# Altium schematic sheet Actuation.SchDoc
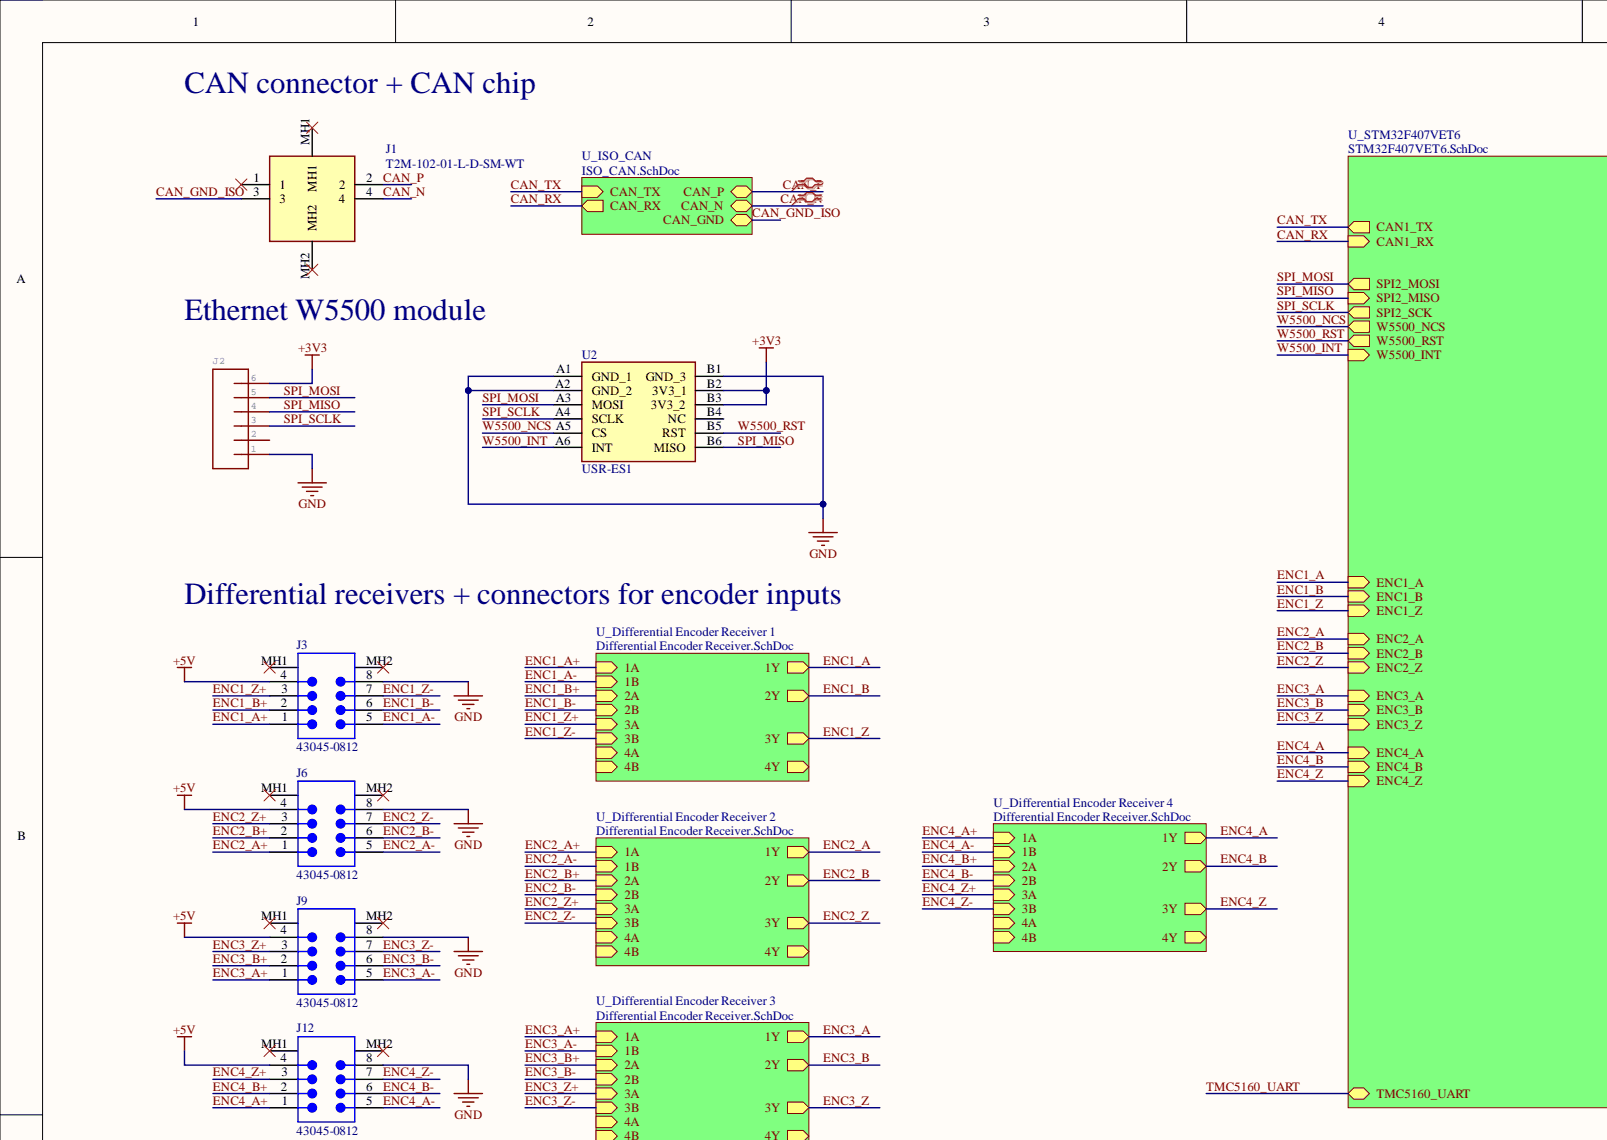
[diagram p0: Actuation.SchDoc, top left]
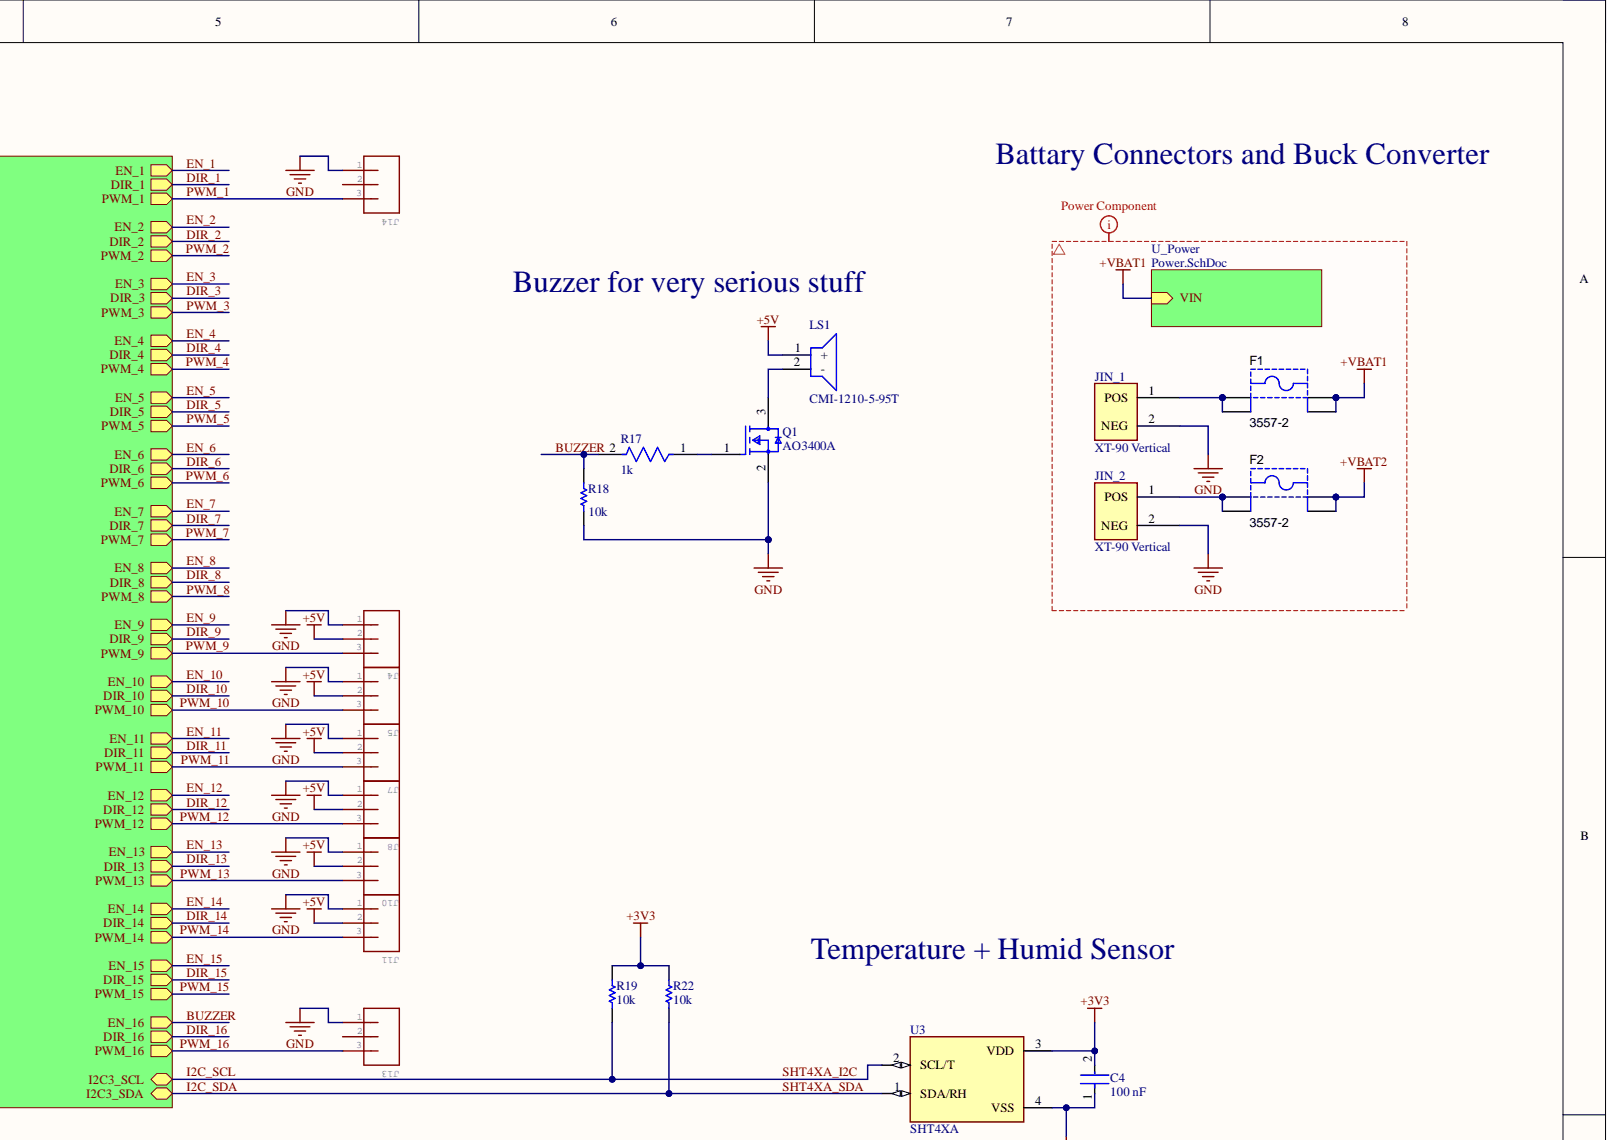
[diagram p1: Actuation.SchDoc, top right]
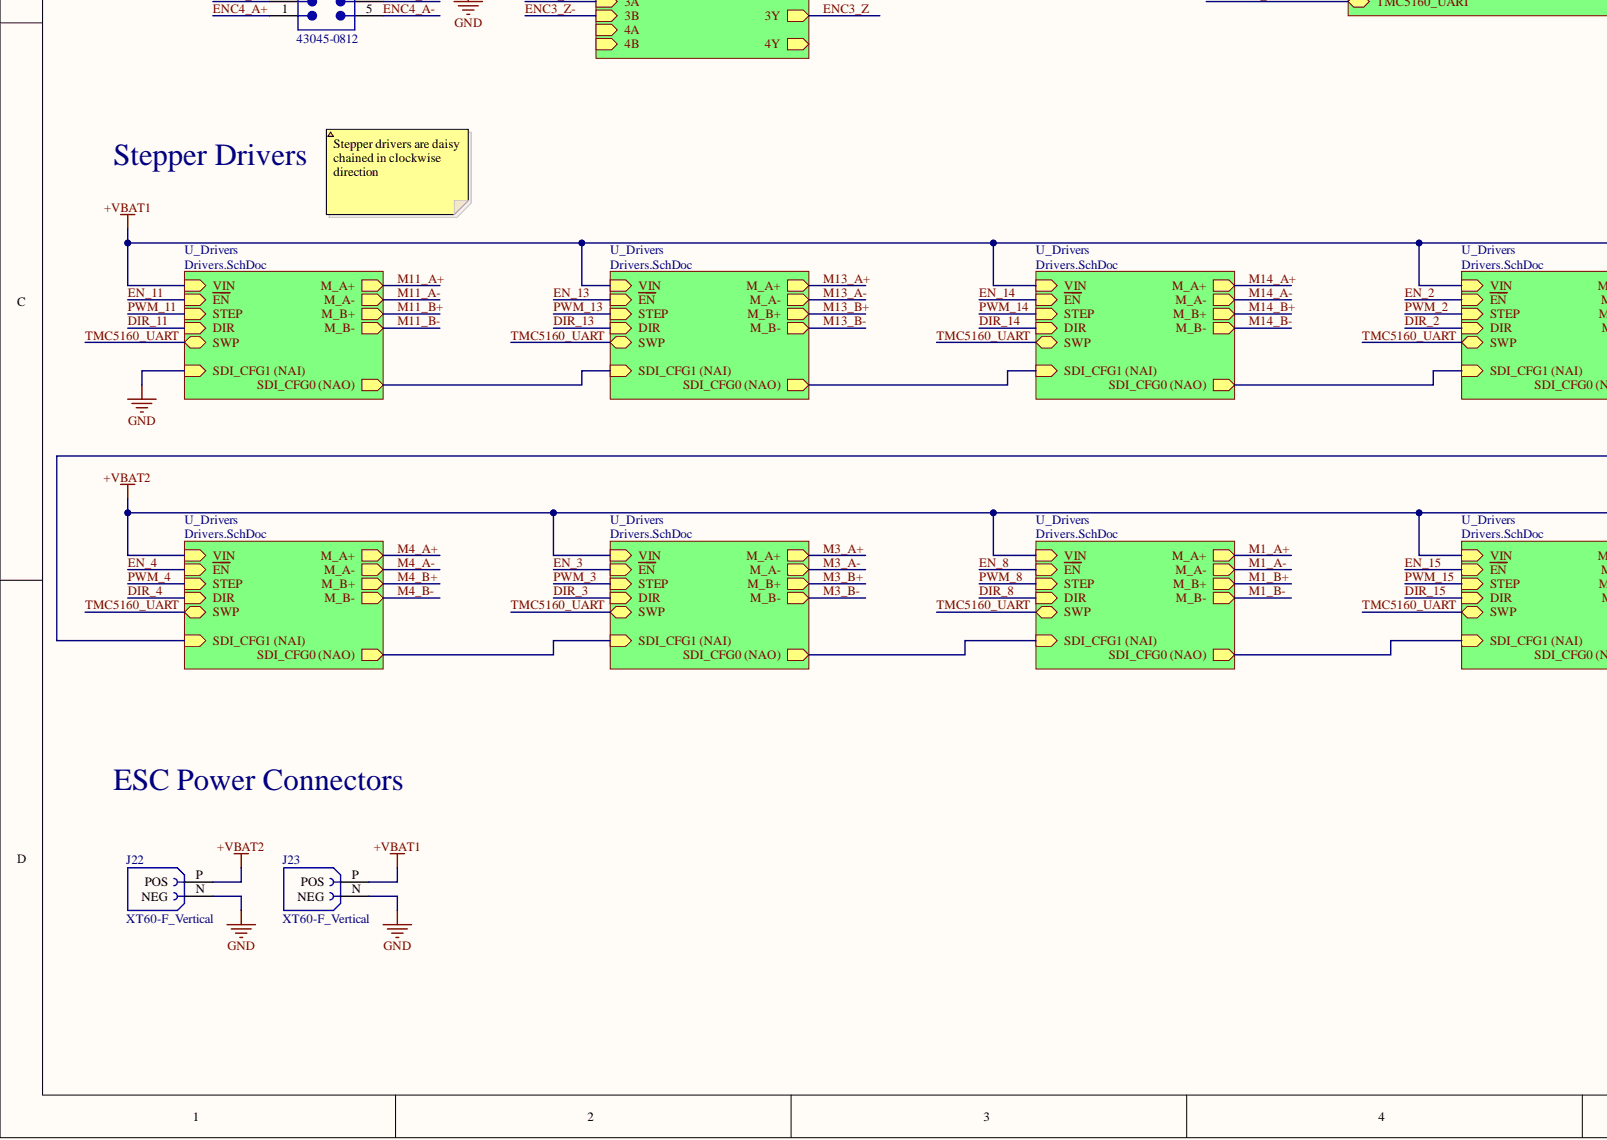
[diagram p2: Actuation.SchDoc, bottom left]
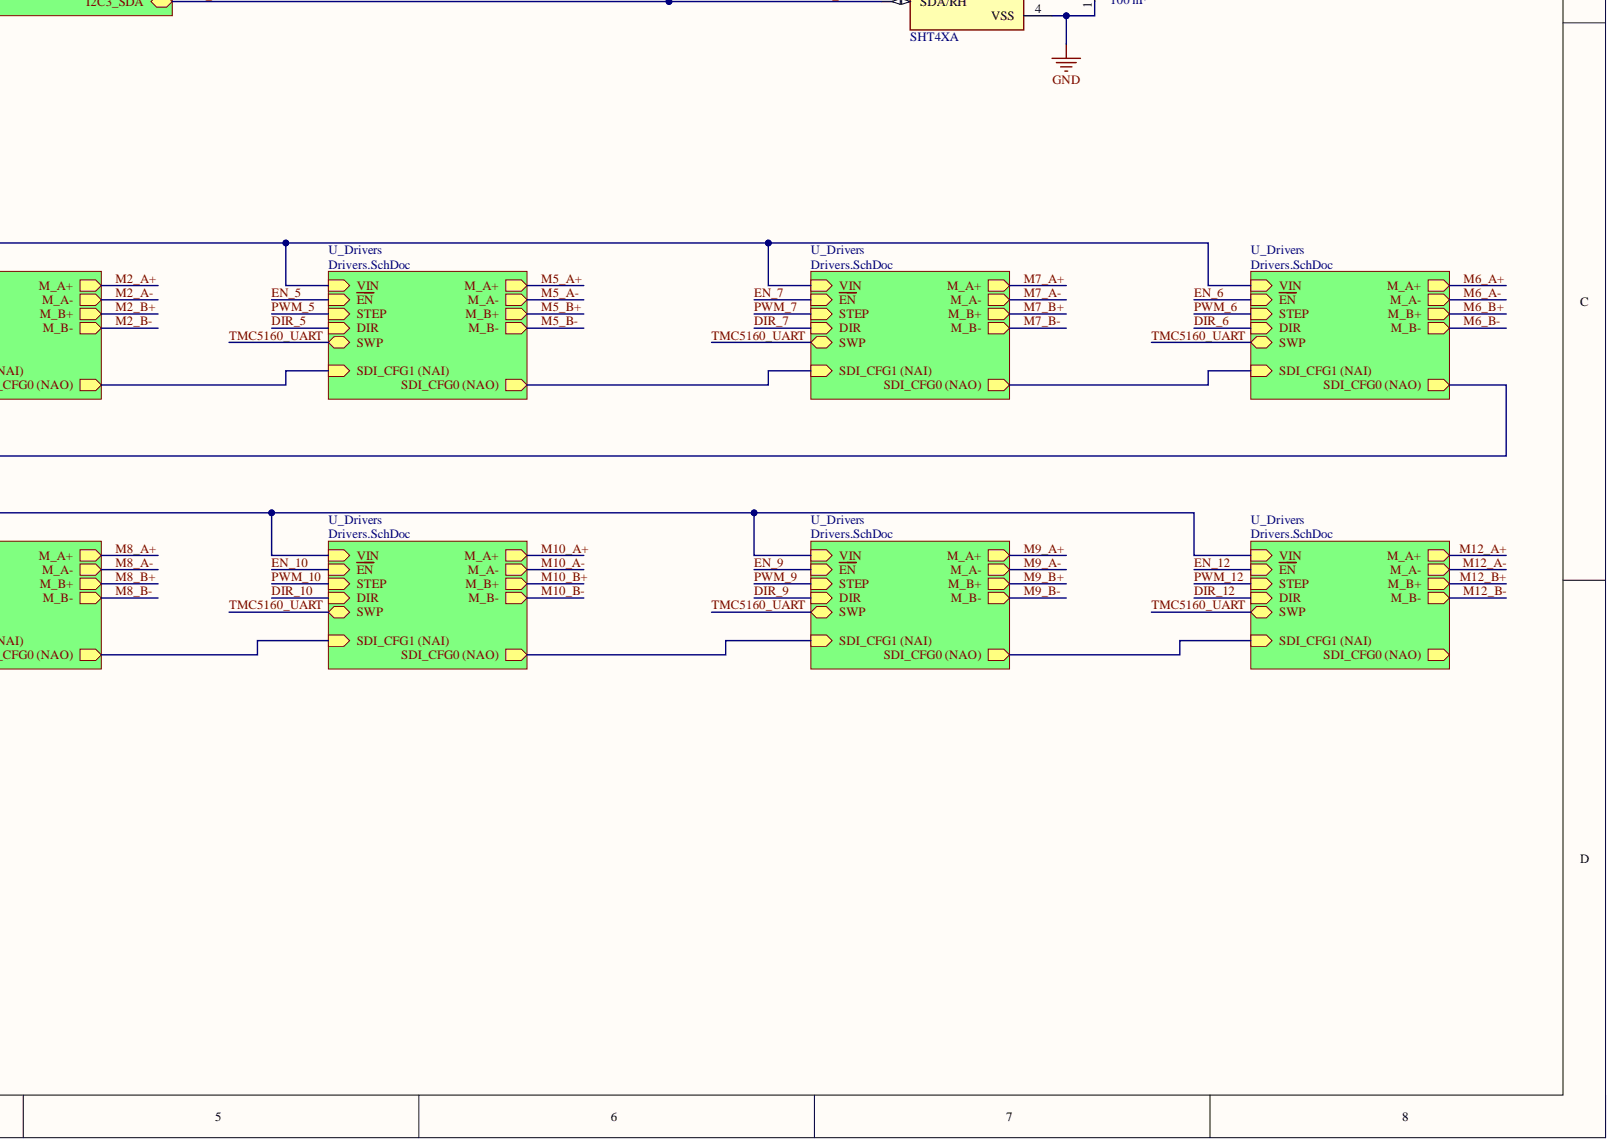
[diagram p3: Actuation.SchDoc, bottom right]

## Parts
C4  value 100 nF  Capacitance: 100 nF  [FP-CC0603-DA-MFG]  (hidden comment: CC0603KRX7R9BB104)
F1  3557-2  [KSTN-3557-2]
F2  3557-2  [KSTN-3557-2]
J1  T2M-102-01-L-D-SM-WT  [T2M10201LDSMWT]
J2  Multi connection point. Often used as Generic Header-pin footprint for 0.1 inch spaced/style header connections    On any of the 0.1 inch spaced packages, you can populate with these:  Break Away Headers - Straight (PRT-00116)   Break Away Male Headers - Right Angle (PRT-00553)   Female Headers (PRT-00115)   Break Away Headers - Machine Pin (PRT-00117)   Break Away Female Headers - Swiss Machine Pin (PRT-00743)   Arduino Stackable Header - 6 Pin (PRT-09280)   Screw Terminals 2.54mm Pitch (6-Pin) (PRT-16499)        For SCREWTERMINALS and SPRING TERMINALS visit here:  Screw Terimnals on SparkFun.com (5mm/3.5mm/2.54mm spacing)       This device is also useful as a general connection point to wire up your design to another part of your project. Our various solder wires solder well into these plated through hole pads.  Hook-Up Wire - Assortment (Stranded, 22 AWG) (PRT-11375)   Hook-Up Wire - Assortment (Solid Core, 22 AWG) (PRT-11367)   View the entire wire category on our website here  [1X06_LOCK]  (value not drawn)
J3  43045-0812  [MOLX-43045-0812_V]
J4  Multi connection point. Often used as Generic Header-pin footprint for 0.1 inch spaced/style header connections    On any of the 0.1 inch spaced packages, you can populate with these:  Break Away Headers - Straight (PRT-00116)   Break Away Male Headers - Right Angle (PRT-00553)   Female Headers (PRT-00115)   Break Away Headers - Machine Pin (PRT-00117)   Break Away Female Headers - Swiss Machine Pin (PRT-00743)   Stackable Header - 3 Pin (Female, 0.1") (PRT-13875)        For SCREWTERMINALS and SPRING TERMINALS visit here:  Screw Terimnals on SparkFun.com (5mm/3.5mm/2.54mm spacing)       This device is also useful as a general connection point to wire up your design to another part of your project. Our various solder wires solder well into these plated through hole pads.  Hook-Up Wire - Assortment (Stranded, 22 AWG) (PRT-11375)   Hook-Up Wire - Assortment (Solid Core, 22 AWG) (PRT-11367)   View the entire wire category on our website here          Special notes:         Molex polarized connector foot print use with SKU : PRT-08232 with associated crimp pins and housings.  [1X03_LOCK]  (value not drawn)
J5  Multi connection point. Often used as Generic Header-pin footprint for 0.1 inch spaced/style header connections    On any of the 0.1 inch spaced packages, you can populate with these:  Break Away Headers - Straight (PRT-00116)   Break Away Male Headers - Right Angle (PRT-00553)   Female Headers (PRT-00115)   Break Away Headers - Machine Pin (PRT-00117)   Break Away Female Headers - Swiss Machine Pin (PRT-00743)   Stackable Header - 3 Pin (Female, 0.1") (PRT-13875)        For SCREWTERMINALS and SPRING TERMINALS visit here:  Screw Terimnals on SparkFun.com (5mm/3.5mm/2.54mm spacing)       This device is also useful as a general connection point to wire up your design to another part of your project. Our various solder wires solder well into these plated through hole pads.  Hook-Up Wire - Assortment (Stranded, 22 AWG) (PRT-11375)   Hook-Up Wire - Assortment (Solid Core, 22 AWG) (PRT-11367)   View the entire wire category on our website here          Special notes:         Molex polarized connector foot print use with SKU : PRT-08232 with associated crimp pins and housings.  [1X03_LOCK]  (value not drawn)
J6  43045-0812  [MOLX-43045-0812_V]
J7  Multi connection point. Often used as Generic Header-pin footprint for 0.1 inch spaced/style header connections    On any of the 0.1 inch spaced packages, you can populate with these:  Break Away Headers - Straight (PRT-00116)   Break Away Male Headers - Right Angle (PRT-00553)   Female Headers (PRT-00115)   Break Away Headers - Machine Pin (PRT-00117)   Break Away Female Headers - Swiss Machine Pin (PRT-00743)   Stackable Header - 3 Pin (Female, 0.1") (PRT-13875)        For SCREWTERMINALS and SPRING TERMINALS visit here:  Screw Terimnals on SparkFun.com (5mm/3.5mm/2.54mm spacing)       This device is also useful as a general connection point to wire up your design to another part of your project. Our various solder wires solder well into these plated through hole pads.  Hook-Up Wire - Assortment (Stranded, 22 AWG) (PRT-11375)   Hook-Up Wire - Assortment (Solid Core, 22 AWG) (PRT-11367)   View the entire wire category on our website here          Special notes:         Molex polarized connector foot print use with SKU : PRT-08232 with associated crimp pins and housings.  [1X03_LOCK]  (value not drawn)
J8  Multi connection point. Often used as Generic Header-pin footprint for 0.1 inch spaced/style header connections    On any of the 0.1 inch spaced packages, you can populate with these:  Break Away Headers - Straight (PRT-00116)   Break Away Male Headers - Right Angle (PRT-00553)   Female Headers (PRT-00115)   Break Away Headers - Machine Pin (PRT-00117)   Break Away Female Headers - Swiss Machine Pin (PRT-00743)   Stackable Header - 3 Pin (Female, 0.1") (PRT-13875)        For SCREWTERMINALS and SPRING TERMINALS visit here:  Screw Terimnals on SparkFun.com (5mm/3.5mm/2.54mm spacing)       This device is also useful as a general connection point to wire up your design to another part of your project. Our various solder wires solder well into these plated through hole pads.  Hook-Up Wire - Assortment (Stranded, 22 AWG) (PRT-11375)   Hook-Up Wire - Assortment (Solid Core, 22 AWG) (PRT-11367)   View the entire wire category on our website here          Special notes:         Molex polarized connector foot print use with SKU : PRT-08232 with associated crimp pins and housings.  [1X03_LOCK]  (value not drawn)
J9  43045-0812  [MOLX-43045-0812_V]
J10  Multi connection point. Often used as Generic Header-pin footprint for 0.1 inch spaced/style header connections    On any of the 0.1 inch spaced packages, you can populate with these:  Break Away Headers - Straight (PRT-00116)   Break Away Male Headers - Right Angle (PRT-00553)   Female Headers (PRT-00115)   Break Away Headers - Machine Pin (PRT-00117)   Break Away Female Headers - Swiss Machine Pin (PRT-00743)   Stackable Header - 3 Pin (Female, 0.1") (PRT-13875)        For SCREWTERMINALS and SPRING TERMINALS visit here:  Screw Terimnals on SparkFun.com (5mm/3.5mm/2.54mm spacing)       This device is also useful as a general connection point to wire up your design to another part of your project. Our various solder wires solder well into these plated through hole pads.  Hook-Up Wire - Assortment (Stranded, 22 AWG) (PRT-11375)   Hook-Up Wire - Assortment (Solid Core, 22 AWG) (PRT-11367)   View the entire wire category on our website here          Special notes:         Molex polarized connector foot print use with SKU : PRT-08232 with associated crimp pins and housings.  [1X03_LOCK]  (value not drawn)
J11  Multi connection point. Often used as Generic Header-pin footprint for 0.1 inch spaced/style header connections    On any of the 0.1 inch spaced packages, you can populate with these:  Break Away Headers - Straight (PRT-00116)   Break Away Male Headers - Right Angle (PRT-00553)   Female Headers (PRT-00115)   Break Away Headers - Machine Pin (PRT-00117)   Break Away Female Headers - Swiss Machine Pin (PRT-00743)   Stackable Header - 3 Pin (Female, 0.1") (PRT-13875)        For SCREWTERMINALS and SPRING TERMINALS visit here:  Screw Terimnals on SparkFun.com (5mm/3.5mm/2.54mm spacing)       This device is also useful as a general connection point to wire up your design to another part of your project. Our various solder wires solder well into these plated through hole pads.  Hook-Up Wire - Assortment (Stranded, 22 AWG) (PRT-11375)   Hook-Up Wire - Assortment (Solid Core, 22 AWG) (PRT-11367)   View the entire wire category on our website here          Special notes:         Molex polarized connector foot print use with SKU : PRT-08232 with associated crimp pins and housings.  [1X03_LOCK]  (value not drawn)
J12  43045-0812  [MOLX-43045-0812_V]
J13  Multi connection point. Often used as Generic Header-pin footprint for 0.1 inch spaced/style header connections    On any of the 0.1 inch spaced packages, you can populate with these:  Break Away Headers - Straight (PRT-00116)   Break Away Male Headers - Right Angle (PRT-00553)   Female Headers (PRT-00115)   Break Away Headers - Machine Pin (PRT-00117)   Break Away Female Headers - Swiss Machine Pin (PRT-00743)   Stackable Header - 3 Pin (Female, 0.1") (PRT-13875)        For SCREWTERMINALS and SPRING TERMINALS visit here:  Screw Terimnals on SparkFun.com (5mm/3.5mm/2.54mm spacing)       This device is also useful as a general connection point to wire up your design to another part of your project. Our various solder wires solder well into these plated through hole pads.  Hook-Up Wire - Assortment (Stranded, 22 AWG) (PRT-11375)   Hook-Up Wire - Assortment (Solid Core, 22 AWG) (PRT-11367)   View the entire wire category on our website here          Special notes:         Molex polarized connector foot print use with SKU : PRT-08232 with associated crimp pins and housings.  [1X03_LOCK]  (value not drawn)
J14  Multi connection point. Often used as Generic Header-pin footprint for 0.1 inch spaced/style header connections    On any of the 0.1 inch spaced packages, you can populate with these:  Break Away Headers - Straight (PRT-00116)   Break Away Male Headers - Right Angle (PRT-00553)   Female Headers (PRT-00115)   Break Away Headers - Machine Pin (PRT-00117)   Break Away Female Headers - Swiss Machine Pin (PRT-00743)   Stackable Header - 3 Pin (Female, 0.1") (PRT-13875)        For SCREWTERMINALS and SPRING TERMINALS visit here:  Screw Terimnals on SparkFun.com (5mm/3.5mm/2.54mm spacing)       This device is also useful as a general connection point to wire up your design to another part of your project. Our various solder wires solder well into these plated through hole pads.  Hook-Up Wire - Assortment (Stranded, 22 AWG) (PRT-11375)   Hook-Up Wire - Assortment (Solid Core, 22 AWG) (PRT-11367)   View the entire wire category on our website here          Special notes:         Molex polarized connector foot print use with SKU : PRT-08232 with associated crimp pins and housings.  [1X03_LOCK]  (value not drawn)
J22  XT60-F_Vertical  [AMASS_XT60-F_1x02_P7.20mm_Vertical]
J23  XT60-F_Vertical  [AMASS_XT60-F_1x02_P7.20mm_Vertical]
JIN_1  XT-90 Vertical  [XT-90 Vertical-Footprint-1]
JIN_2  XT-90 Vertical  [XT-90 Vertical-Footprint-1]
LS1  CMI-1210-5-95T  [CMI1210595T]
Q1  AO3400A  [FP-SOT23-MFG]
R17  1k  [FP-RC0603-0_55-IPC_C]
R18  Resistance: 10k  [RESC1609X50X30NL8T20]  (hidden comment: 0603WAF1002T5E)
R19  Resistance: 10k  [RESC1609X50X30NL8T20]  (hidden comment: 0603WAF1002T5E)
R22  Resistance: 10k  [RESC1609X50X30NL8T20]  (hidden comment: 0603WAF1002T5E)
U2  USR-ES1  [USRES1]
U3  SHT4XA  [SHT4XA]

## Nets
+3V3: C4.2 J2.6 R19.2 R22.2 U2.B2(3V3_1) U2.B3(3V3_2) U3.3(VDD)
+5V: J3.4 J4.2 J5.2 J6.4 J7.2 J8.2 J9.4 J10.2 J11.2 J12.4 LS1.1
+VBAT1: F1.3 F1.4 J23.P
+VBAT2: F2.3 F2.4 J22.P
GND: C4.1 J2.1 J3.8 J4.1 J5.1 J6.8 J7.1 J8.1 J9.8 J10.1 J11.1 J12.8 J13.1 J14.1 J22.N J23.N JIN_1.2 JIN_2.2 Q1.2 R18.2 U2.A1(GND_1) U2.A2(GND_2) U2.B1(GND_3) U3.4(VSS)
BUZZER: R17.2 R18.1
CAN_GND_ISO: J1.3
CAN_N: J1.4
CAN_P: J1.2
ENC1_A+: J3.1
ENC1_A-: J3.5
ENC1_B+: J3.2
ENC1_B-: J3.6
ENC1_Z+: J3.3
ENC1_Z-: J3.7
ENC2_A+: J6.1
ENC2_A-: J6.5
ENC2_B+: J6.2
ENC2_B-: J6.6
ENC2_Z+: J6.3
ENC2_Z-: J6.7
ENC3_A+: J9.1
ENC3_A-: J9.5
ENC3_B+: J9.2
ENC3_B-: J9.6
ENC3_Z+: J9.3
ENC3_Z-: J9.7
ENC4_A+: J12.1
ENC4_A-: J12.5
ENC4_B+: J12.2
ENC4_B-: J12.6
ENC4_Z+: J12.3
ENC4_Z-: J12.7
I2C_SCL: R19.1 U3.2(SCL/T)
I2C_SDA: R22.1 U3.1(SDA/RH)
PWM_1: J14.3
PWM_9: J4.3
PWM_10: J5.3
PWM_11: J7.3
PWM_12: J8.3
PWM_13: J10.3
PWM_14: J11.3
PWM_16: J13.3
SPI_MISO: J2.4 U2.B6(MISO)
SPI_MOSI: J2.5 U2.A3(MOSI)
SPI_SCLK: J2.3 U2.A4(SCLK)
W5500_INT: U2.A6(INT)
W5500_NCS: U2.A5(CS)
W5500_RST: U2.B5(RST)
NetF1_1: F1.1 F1.2 JIN_1.1
NetF2_1: F2.1 F2.2 JIN_2.1
NetJ1_1: J1.1
NetJ1_MH1: J1.MH1
NetJ1_MH2: J1.MH2
NetJ2_2: J2.2
NetJ3_MH1: J3.MH1
NetJ3_MH2: J3.MH2
NetJ6_MH1: J6.MH1
NetJ6_MH2: J6.MH2
NetJ9_MH1: J9.MH1
NetJ9_MH2: J9.MH2
NetJ12_MH1: J12.MH1
NetJ12_MH2: J12.MH2
NetJ13_2: J13.2
NetJ14_2: J14.2
NetLS1_2: LS1.2 Q1.3
NetQ1_1: Q1.1 R17.1
NetU2_B4: U2.B4(NC)
4A:
4B:
4Y:
CAN_RX:
CAN_TX:
DIR_1:
DIR_2:
DIR_3:
DIR_4:
DIR_5:
DIR_6:
DIR_7:
DIR_8:
DIR_9:
DIR_10:
DIR_11:
DIR_12:
DIR_13:
DIR_14:
DIR_15:
DIR_16:
EN_1:
EN_2:
EN_3:
EN_4:
EN_5:
EN_6:
EN_7:
EN_8:
EN_9:
EN_10:
EN_11:
EN_12:
EN_13:
EN_14:
EN_15:
ENC1_A:
ENC1_B:
ENC1_Z:
ENC2_A:
ENC2_B:
ENC2_Z:
ENC3_A:
ENC3_B:
ENC3_Z:
ENC4_A:
ENC4_B:
ENC4_Z:
M1_A+:
M1_A-:
M1_B+:
M1_B-:
M2_A+:
M2_A-:
M2_B+:
M2_B-:
M3_A+:
M3_A-:
M3_B+:
M3_B-:
M4_A+:
M4_A-:
M4_B+:
M4_B-:
M5_A+:
M5_A-:
M5_B+:
M5_B-:
M6_A+:
M6_A-:
M6_B+:
M6_B-:
M7_A+:
M7_A-:
M7_B+:
M7_B-:
M8_A+:
M8_A-:
M8_B+:
M8_B-:
M9_A+:
M9_A-:
M9_B+:
M9_B-:
M10_A+:
M10_A-:
M10_B+:
M10_B-:
M11_A+:
M11_A-:
M11_B+:
M11_B-:
M12_A+:
M12_A-:
M12_B+:
M12_B-:
M13_A+:
M13_A-:
M13_B+:
M13_B-:
M14_A+:
M14_A-:
M14_B+:
M14_B-:
PWM_2:
PWM_3:
PWM_4:
PWM_5:
PWM_6:
PWM_7:
PWM_8:
PWM_15:
SDI_CFG0 (NAO):
TMC5160_UART:

## Library data of the parts
C4 = CMP-2100-03601-2: CAP CER 0.1UF 50V X7R 0603; Manufacturer: YAGEO; Material: Ceramic; Temperature Coefficient: 15%; Tolerance: 0.1; Automotive: No; Category: Cap; Component Kind: Standard; ComponentLink2Description: Datasheet; ComponentLink2URL: http://www.yageo.com/documents/recent/UPY-GPHC_X7R_6.3V-to-50V_18.pdf; Device Class L1: Passive Components; Device Class L2: Capacitors; Device Class L3: Ceramic Capacitors; Digikey Description: CAP CER 0.1UF 50V X7R 0603; Footprint Url: http://www.yageo.com/exep/pages/download/literatures/UPY-C_GEN_24.pdf; Height: 0.9mm; Lead Free: Yes; Library Name: Altium Content Vault; Manufacturer Part Number: CC0603KRX7R9BB104; Mouser Description: Multilayer Ceramic Capacitor, CC Series, 0.1 - F, - 10%, X7R, 50 V, 0603 [1608 Metric]; Octopart: https://octopart.com/cc0603krx7r9bb104-yageo-42244635; Package: CC0603-DA; RoHS: TRUE; Temperature: -55°C to +125°C; Temperature Characteristic: X7R; Temperature Range High: +125°C; Temperature Range Low: -55°C; Voltage: 50 V; Voltage Rating: 50 V
F1 F2 = CMP-2000-05461-1: FUSE HOLDER, PCB MOUNT, 500VAC, 20A, ROHS; Manufacturer: Keystone-Electronics; Current Rating: 30A; For Use With/Related Products: ATC,ATO257,MINI897; Fuse Size: 0.43"Lx0.15"Wx0.64"H(10.92mmx3.81mmx16.25mm); Fuse Type: Blade; Fuseholder Type: Block; Number of Circuits: 1; Voltage: 500V; Category: CircuitProtection; Component Kind: Standard; Contact Finish: TinNickel; Contact Material: Brass; Datasheet URL: http://datasheet.octopart.com/3557-2-Keystone-datasheet-15715937.pdf; Datasheet Version: Apr-13; Family: Fuseholders; Library Name: Altium Content Vault; Manufacturer URL: http://www.keyelco.com/; Mounting Type: PCB; Orientation: Vertical; Series: -; Termination Style: PCPin
J1 = CMP-062-000000-1: Connector; Manufacturer_Name: SAMTEC; Component Kind: Standard; Datasheet Link: https://suddendocs.samtec.com/prints/t2m-1xx-xx-x-d-xx-xx-x-xx-mkt.pdf?_gl=1*1h8jfhh*_ga*ODgwNjE2ODg0LjE2NDkxNjE4OTQ.*_ga_3KFNZC07WW*MTY4MDE1NTk5OS4xMjYuMC4xNjgwMTU2MDg2LjYwLjAuMA..; Design Item ID: T2M-102-01-L-D-SM-WT; Height: 6.68mm; Manufacturer_Part_Number: T2M-102-01-L-D-SM-WT; Mouser Part Number: 200-T2M10201LDSMWT; Mouser Price/Stock: https://www.mouser.co.uk/ProductDetail/Samtec/T2M-102-01-L-D-SM-WT?qs=PB6%2FjmICvI1RhUpc5sXmcA%3D%3D; Source: SamacSys.SchLib; Library Name: BumbleBee Autonomous Systems - NUS
J2 = CONN_06LOCK: DeviceName: LOCK; GateName_1: J1; Component Kind: Standard; DeviceSetName: CONN_06; Library Name: SparkFun-Connectors.Library.IntLib; LibraryName: SparkFun-Connectors; Package: 1X06_LOCK; SymbolName_1: CONN_06
J3 J6 J9 J12 = CMP-1594-00003-1: 3 mm Pitch Micro-Fit 3.0 Connector, 17.27 mm Mated Height, Vertical, Through Hole, 8 Circuits, -40 to 105 degC, RoHS, Tray; No. of Positions: 8; No. of Rows: 2; Pitch: 3 mm; Component Kind: Standard; ComponentLink1Description: Manufacturer URL; ComponentLink1URL: http://www.molex.com/; ComponentLink2Description: Datasheet; ComponentLink2URL: http://www.molex.com/webdocs/datasheets/pdf/en-us/0430450812_PCB_HEADERS.pdf; ComponentLink3Description: Package Specification; ComponentLink3URL: http://www.molex.com/pdm_docs/sd/430450812_sd.pdf; Library Name: Altium Content Vault; Mounting Technology: Through Hole; Orientation: Vertical; PackageDescription: 8-Pin PCB Header, Vertical, Pitch 3 mm, Mated Height 17.27 mm, Through Hole; PackageVersion: Rev. F, 06/2009; Packing: Tray; PartNumber: 43045-0812; RoHS: TRUE; Temperature Max: 105 degC; Temperature Min: -40 degC
J4 J5 J7 J8 J10 J11 J13 J14 = CONN_03LOCK: DeviceName: LOCK; GateName_1: J1; Component Kind: Standard; DeviceSetName: CONN_03; Library Name: SparkFun-Connectors.Library.IntLib; LibraryName: SparkFun-Connectors; Package: 1X03_LOCK; SymbolName_1: CONN_03
J22 J23 = CMP-141-000024-1: Component Kind: Standard; Library Name: BumbleBee Autonomous Systems - NUS
JIN_1 JIN_2 = CMP-141-000008-1: Component Kind: Standard; ComponentLink1Description: Mech Drawing; ComponentLink1URL: https://components101.com/connectors/xt90-battery-connector; Description: XT-90 Vertical; Library Name: BumbleBee Autonomous Systems - NUS
LS1 = CMI-1210-5-95T: Loudspeaker or Buzzer; Manufacturer_Name: CUI Devices; Component Kind: Standard; Datasheet Link: https://www.cuidevices.com/product/resource/cmi-1210-5-95t.pdf; Height: 10mm; Manufacturer_Part_Number: CMI-1210-5-95T; Mouser Part Number: 179-CMI-1210-5-95T; Mouser Price/Stock: https://www.mouser.co.uk/ProductDetail/CUI-Devices/CMI-1210-5-95T?qs=Rp5uXu7WBW9WJf9tZv97Vg%3D%3D
Q1 = CMP-83955-000006-1: MOSFET N-CH 30V 5.7A SOT23; Gate to Source Voltage (Vgs): 12V; Input Capacitance: 630pF; Max Power Dissipation: 1.4W; Number of Elements: 1; Rds On Max: 26.5mR; Case/Package: SOT-23; Component Kind: Standard; Continuous Drain Current (ID): 5.7A; Drain to Source Voltage (Vdss): 30V; Lead Free: Lead Free; Library Name: Altium Content Vault; Max Operating Temperature: 150°C; Min Operating Temperature: -55°C; Mount: Surface Mount; Number of Pins: 3; Packaging: Tape and Reel; Power Dissipation: 1.4W; Radiation Hardening: No
R17 = CMP-1659-00009-5: RC0603FR-071KL. RES 1K OHM 1% 1/10W 0603; Max Power Dissipation: 100mW; Resistance: 1kR; Temperature Coefficient: 100ppm/°C; Voltage Rating: 50V; Case Code (Imperial): 0603; Case Code (Metric): 1608; Case/Package: 0603; Component Kind: Standard; Construction: Rectangular; Contact Plating: Tin; Depth: 0.8mm; Features: Moisture Resistant; Height: 0.45mm; Lead Free: Lead Free; Length: 1.6mm; Library Name: Altium Content Vault; Max Operating Temperature: 155°C; Military Standard: Not; Min Operating Temperature: -55°C; Number of Terminations: 2; Packaging: Tape and Reel; Power Rating: 100mW; Radiation Hardening: No; REACH SVHC: No SVHC; Resistance Tolerance: 1%; Resistor Type: Thick Film; RoHS Compliant: Yes; Series: RC; Size Code: 0603; Technology: THICK FILM; Termination: SMD/SMT; Tolerance: 1%
R18 R19 R22 = CMP-1666-00005-4: 100mW Thick Film Resistors 75V ±100ppm/℃ ±1% 10kΩ 0603 Chip Resistor - Surface Mount ROHS; Component Kind: Standard; ComponentLink1Description: Manufacturer URL; ComponentLink1URL: http://www.vishay.com/; ComponentLink2Description: Datasheet; ComponentLink2URL: https://datasheet.ciiva.com/11809/0900766b80d98afc-11809037.pdf; Height: 0.45 mm; Length: 1.55 mm; Library Name: Altium Content Vault; Max Operating Temperature: 155 degC; Min Operating Temperature: -55 degC; Mounting Technology: Surface Mount; Package Quantity: 5000; PackageDescription: 2-Pin Surface Mount Device, Body 1.575 x 0.85 mm; PackageReference: 0603; Packaging: Tape and Reel; REACH SVHC: Unknown; RoHSCompliant: true; Voltage Rating: 75 V
U2 = CMP-065-000000-2: Integrated Circuit; Manufacturer_Name: Smart Electronics; Component Kind: Standard; Datasheet Link: https://www.aliexpress.com/item/Free-Shipping-USR-ES1-W5500-Chip-New-SPI-to-LAN-Ethernet-Converter-TCP-IP-Mod/32714438223.html?spm=a2g0s.9042311.0.0.27424c4dBBEz2G; Design Item ID: USR-ES1; Height: 3mm; Manufacturer_Part_Number: USR-ES1; Source: SamacSys.SchLib; Library Name: BumbleBee Autonomous Systems - NUS
U3 = CMP-163-000000-1: Automotive Grade Relative Humidity Temperature Sensor, Â±3 %RH, Â±0.3 Â°C; MF: Sensirion; MP: SHT40A-AD1B-R3; Availability: Not in stock; Check_prices: https://www.snapeda.com/parts/SHT40A-AD1B-R3/Sensirion/view-part/?ref=eda; Component Kind: Standard; Component Type: Standard; Design Item ID: SHT4XA; Package: DFN-4 Sensirion; Pin Count: 4; Price: None; SnapEDA_Link: https://www.snapeda.com/parts/SHT40A-AD1B-R3/Sensirion/view-part/?ref=snap; Source: SHT4XA.SchLib; Library Name: BumbleBee Autonomous Systems - NUS
